# Altium schematic sheet Sheet1.SchDoc
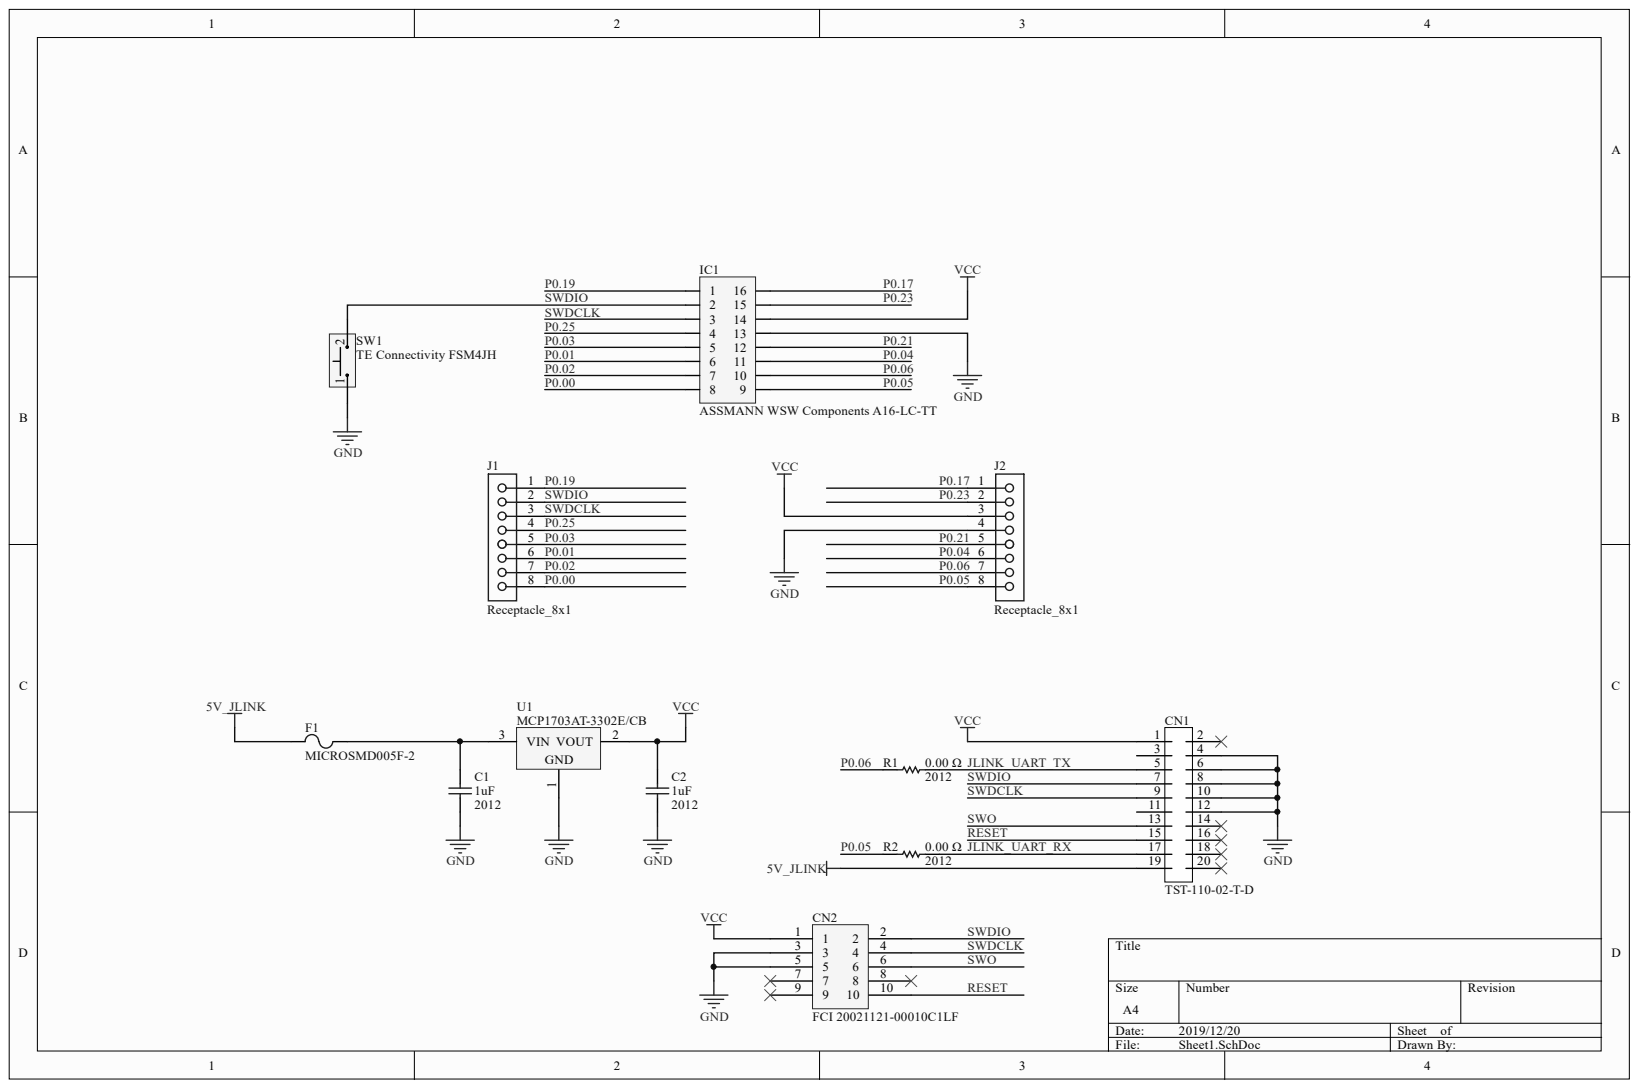
[diagram p0: Sheet1.SchDoc]

## Parts
C1  1uF  Package: 2012  [PCB-42k0wz70n3krnaxutxxn-1]
C2  1uF  Package: 2012  [PCB-42k0wz70n3krnaxutxxn-1]
CN1  TST-110-02-T-D  [PCB-ec5iovcojvq23t43n52k-1]
CN2  FCI 20021121-00010C1LF  [PCB-ch0b5fvyy24ict2e1x4o-1]
F1  MICROSMD005F-2  [PCB-tiinbo77us21pxzhxou9-1]
IC1  ASSMANN WSW Components A16-LC-TT  [PCB-mxw97o43vdeffel1th99-1]
J1  Receptacle_8x1  [PCB-rk0xvjxtj9hjg7xlxwg2-1]
J2  Receptacle_8x1  [PCB-rk0xvjxtj9hjg7xlxwg2-1]
R1  Case/Package (SI): 2012  Resistance: 0.00 Ω  [PCB-bl2akml0p6ls1gsr5x85-1]  (hidden comment: SYM-2b1be232cbe2f4dc-3)
R2  Case/Package (SI): 2012  Resistance: 0.00 Ω  [PCB-bl2akml0p6ls1gsr5x85-1]  (hidden comment: SYM-2b1be232cbe2f4dc-3)
SW1  TE Connectivity FSM4JH  [PCB-ig79pzg5mdpht1qqvy53-1]
U1  MCP1703AT-3302E/CB  [MCHP-SOT-23A-CB3_M]

## Nets
5V_JLINK: CN1.19 F1.1
GND: C1.1 C2.1 CN1.4 CN1.6 CN1.8 CN1.10 CN1.12 CN2.3 CN2.5 IC1.13 J2.4 SW1.1 U1.1
VCC: C2.2 CN1.1 CN2.1 IC1.14 J2.3 U1.2
JLINK_UART_RX: CN1.17 R2.2
JLINK_UART_TX: CN1.5 R1.2
P0.00: IC1.8 J1.8
P0.01: IC1.6 J1.6
P0.02: IC1.7 J1.7
P0.03: IC1.5 J1.5
P0.04: IC1.11 J2.6
P0.05: IC1.9 J2.8 R2.1
P0.06: IC1.10 J2.7 R1.1
P0.17: IC1.16 J2.1
P0.19: IC1.1 J1.1
P0.21: IC1.12 J2.5
P0.23: IC1.15 J2.2
P0.25: IC1.4 J1.4
RESET: CN1.15 CN2.10
SWDCLK: CN1.9 CN2.4 IC1.3 J1.3
SWDIO: CN1.7 CN2.2 IC1.2 J1.2 SW1.2
SWO: CN1.13 CN2.6
NetC1_2: C1.2 F1.2 U1.3

Not connected: CN1 2 3 11 14 16 18 20; CN2 7 8 9

## Library data of the parts
C1 C2 = CMP-e33b2a5a2ac03cfc-3: Cap Ceramic 1uF 16V X7R 10% SMD 0805 125°C Embossed T/R; Manufacturer Part Number: EMK212B7105KG-T; Lead-Free Status: Lead Free; Component Kind: Standard; Dielectric Characteristic: X7R; Library Name: CommunityVault; Mounting Style: Surface Mount; Octopart URL: https://octopart.com/emk212b7105kg-t-taiyo+yuden-7072925; Operating Temperature: -55.0 °C to 125 °C
CN1 = CMP-8801404e51a057c6-7: Conn Header Vert 20pos Gold; Component Kind: Standard; Library Name: CommunityVault
CN2 = CMP-c6d60ecf2c091911-2: Conn Unshrouded Header HDR 10 POS 1.27mm Solder ST SMD T/R; Component Kind: Standard; Library Name: CommunityVault; OctopartID: c6d60ecf2c091911; Octopart URL: https://octopart.com/20021121-00010c1lf-fci-18075577
F1 = CMP-6220af462900bde7-1: PTC Resettable Fuse 0.5A(hold) 1A(trip) 13.2VDC 40A 0.8W 0.05s 0.25Ohm SMD Solder Pad 1210 T/R Automotive; Component Kind: Standard; Library Name: CommunityVault
IC1 = CMP-50f099ef39c08f37-5: 16 Pin Low Cost 2.54 mm Pitch Tin Plated Contacts IC Socket; Component Kind: Standard; Library Name: CommunityVault
J1 J2 = CMP-674378-7: Female, 8C, Straight, .100"CC; 3.20mm Tail, No Mt. 100u" Tin; PBT, Phos Bronze, 105 C; Manufacturer Part Number: PPTC081LFBN-RC; Lead-Free Status: Lead Free; Number of Positions: 8; Pin Pitch: 2.54 mm; Color: Black; Component Kind: Standard; Contact Material: Phosphor Bronze; Contact Plating: Tin; Gender: Female; Housing Material: Plastic; Library Name: CommunityVault; Mounting Style: Through Hole; Number of Rows: 1; Octopart URL: https://octopart.com/pptc081lfbn-rc-sullins-271024; Operating Temperature: 125 °C (max); Packaging: Bulk; RoHS: Compliant; Size-Height: 8.50 mm; Size-Width: 2.50 mm
R1 R2 = CMP-2b1be232cbe2f4dc-3: Res Thick Film 0805 0 Ohm Molded SMD SMD Paper Tape on Plastic Reel; Power Rating: 125 mW; Resistance Tolerance: ±5%; Temperature Coefficient: -200 ppm/°C to 200 ppm/°C; Voltage Rating (DC): 150 V; Component Kind: Standard; Library Name: CommunityVault; Mounting Style: Surface Mount; Operating Temperature: -55.0 °C to 155 °C; Packaging: Tape & Reel (TR), Cut Tape (CT); REACH SVHC Compliance: No SVHC; Size-Length: 2.01 mm; Size-Width: 1.24 mm
SW1 = CMP-49fa8280b69c963d-3: Switch Tactile OFF (ON) SPST Round Button PC Pins 0.05A 24VDC 100000Cycles 1.57N Thru-Hole Loose; Component Kind: Standard; Library Name: CommunityVault
U1 = CMP-4363702-2: 250 mA, 16V, Low Quiescent Current LDO Regulator, -40 to 125 degC, 3-pin SOT-23A (CB3), Tape and Reel; Lead-Free Status: Lead Free; Output Capacitor Type: Ceramic; Quiescent Current: 2e-06 A; Component Kind: Standard; Library Name: CommunityVault; Lifecycle Status: Active; Manufacturer Part Number: MCP1703AT-3302E/CB; Number of Pins: 3; OctopartID: 0355cb0ce067dc92; Octopart Url: https://octopart.com/mcp1703at-3302e%2Fcb-microchip-22267476; REACH SVHC Compliance: No SVHC; RoHS: Compliant
